# Altium schematic sheet Analog.SchDoc(Analog)
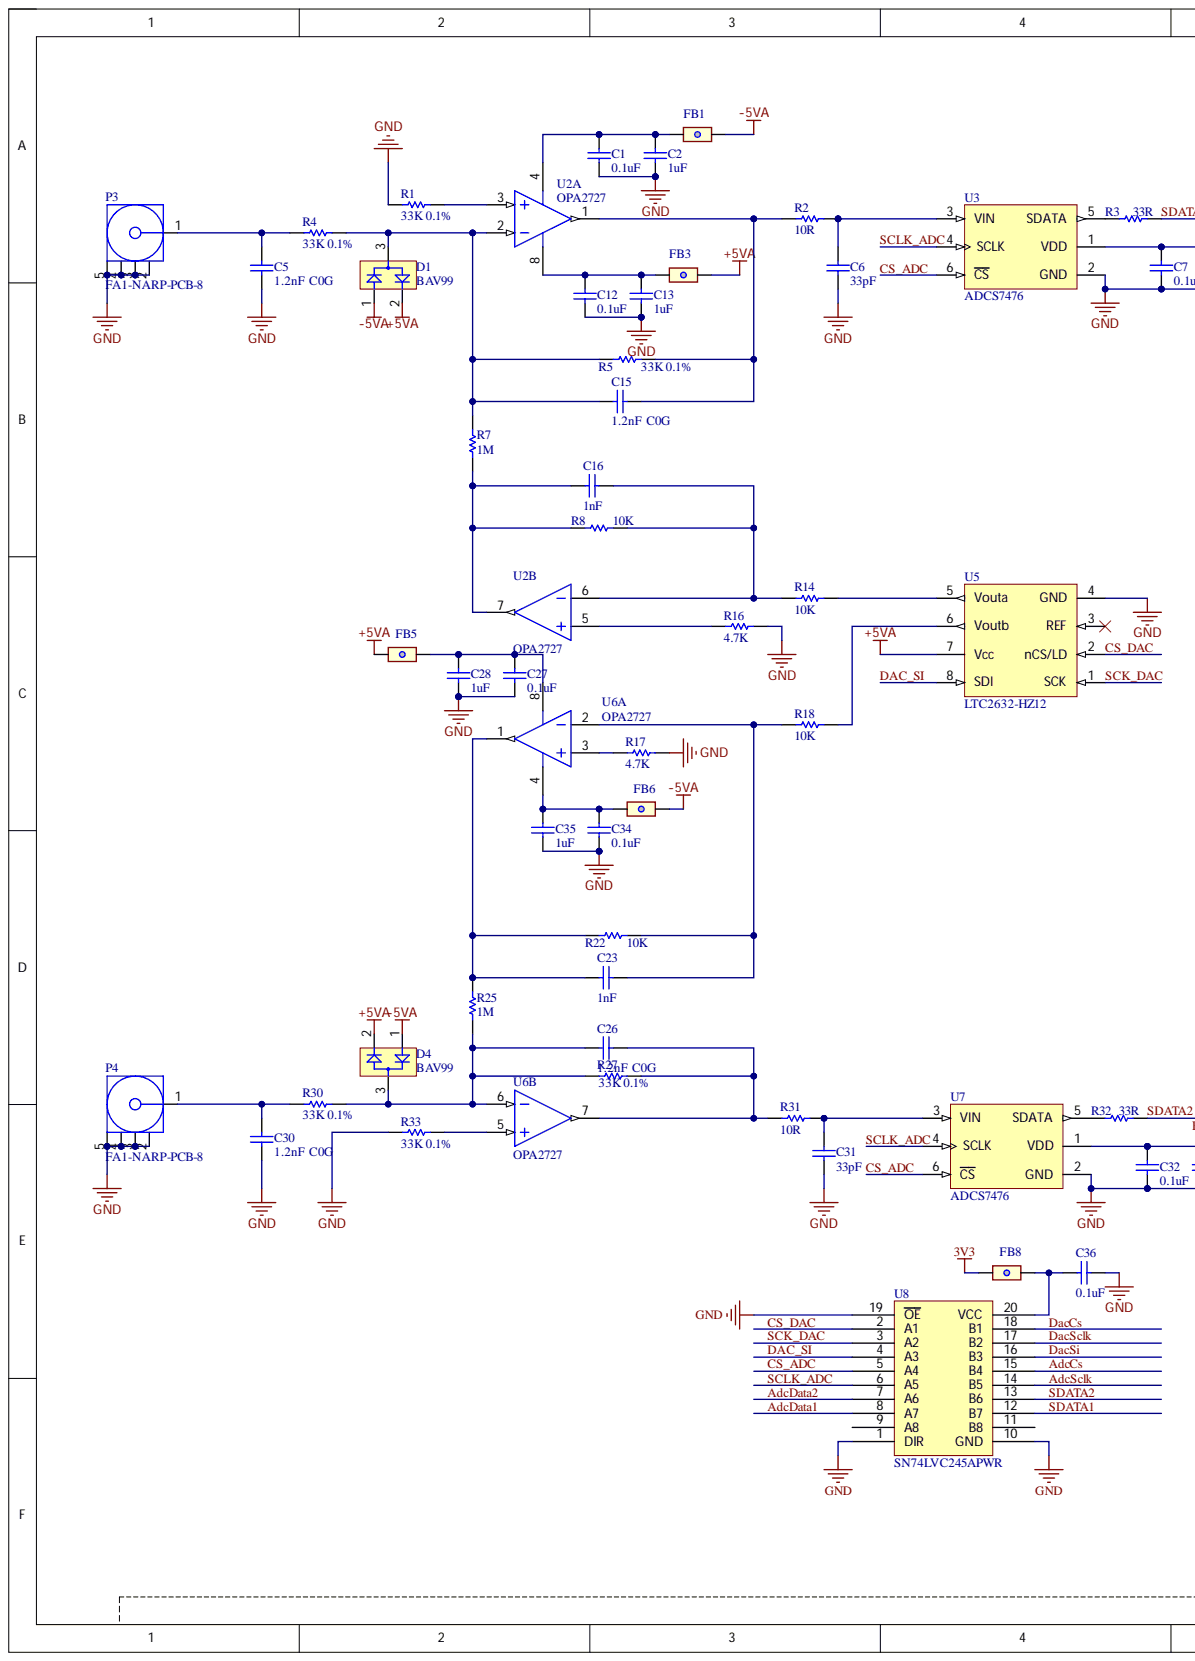
[diagram p0: Analog.SchDoc, left]
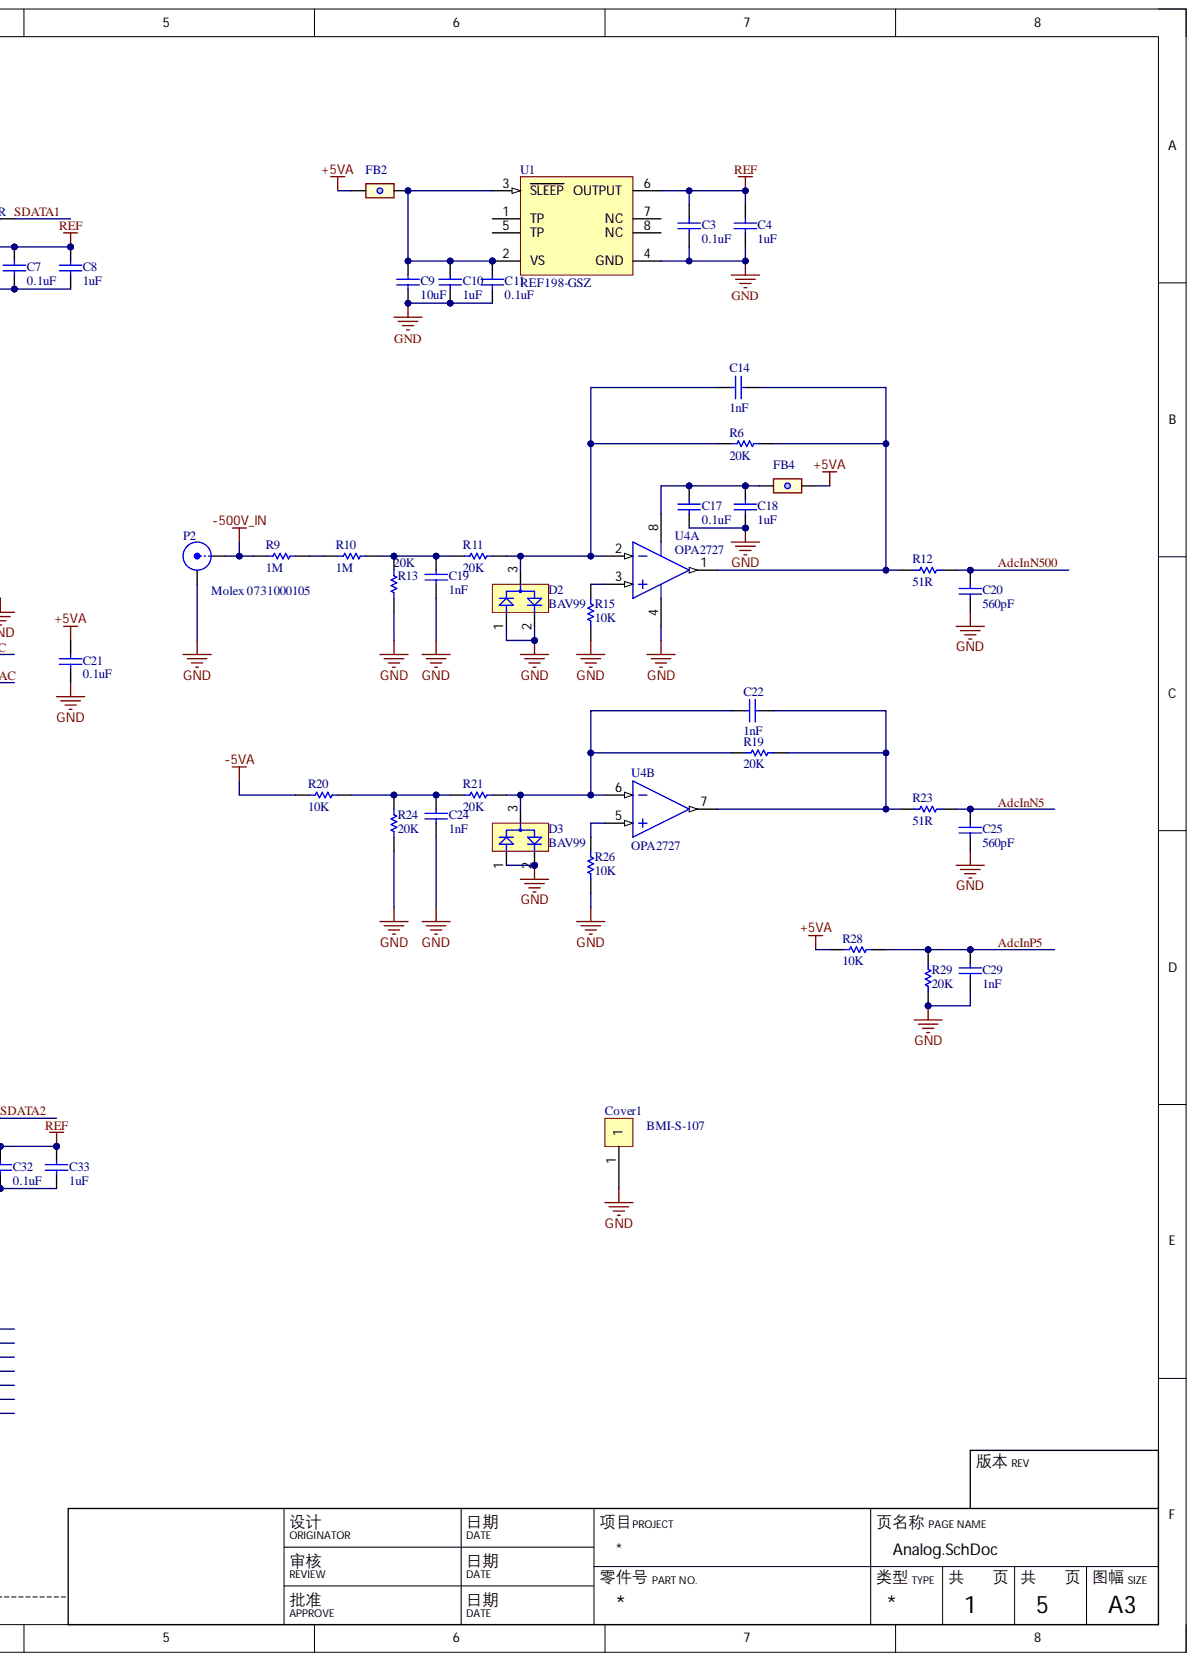
[diagram p1: Analog.SchDoc, right]

## Parts
C1  0.1uF  [C0603(1608)]
C2  1uF  [C0603(1608)]
C3  0.1uF  [C0603(1608)]
C4  1uF  [C0603(1608)]
C5  1.2nF  C0G  [C0603(1608)]
C6  33pF  [C0603(1608)]
C7  0.1uF  [C0603(1608)]
C8  1uF  [C0603(1608)]
C9  10uF  [C1210(3225)]
C10  1uF  [C0603(1608)]
C11  0.1uF  [C0603(1608)]
C12  0.1uF  [C0603(1608)]
C13  1uF  [C0603(1608)]
C14  1nF  [C0603(1608)]
C15  1.2nF  C0G  [C0603(1608)]
C16  1nF  [C0603(1608)]
C17  0.1uF  [C0603(1608)]
C18  1uF  [C0603(1608)]
C19  1nF  [C0603(1608)]
C20  560pF  [C0603(1608)]
C21  0.1uF  [C0603(1608)]
C22  1nF  [C0603(1608)]
C23  1nF  [C0603(1608)]
C24  1nF  [C0603(1608)]
C25  560pF  [C0603(1608)]
C26  1.2nF  C0G  [C0603(1608)]
C27  0.1uF  [C0603(1608)]
C28  1uF  [C0603(1608)]
C29  1nF  [C0603(1608)]
C30  1.2nF  C0G  [C0603(1608)]
C31  33pF  [C0603(1608)]
C32  0.1uF  [C0603(1608)]
C33  1uF  [C0603(1608)]
C34  0.1uF  [C0603(1608)]
C35  1uF  [C0603(1608)]
C36  0.1uF  [C0402(1005)]
Cover1  BMI-S-107  [BMI-S-107]
D1  BAV99  [SOT23-3]
D2  BAV99  [SOT23-3]
D3  BAV99  [SOT23-3]
D4  BAV99  [SOT23-3]
FB1  (no value)  [FB0603(1608)]
FB2  (no value)  [FB0603(1608)]
FB3  (no value)  [FB0603(1608)]
FB4  (no value)  [FB0603(1608)]
FB5  (no value)  [FB0603(1608)]
FB6  (no value)  [FB0603(1608)]
FB8  (no value)  [FB0603(1608)]
P2  Molex 0731000105  制造商: Molex  型号: 0731000105  [Molex 0731000105]
P3  FA1-NARP-PCB-8  [SMB]
P4  FA1-NARP-PCB-8  [SMB]
R1  33K 0.1%  [R0603(1608)]
R2  10R  [R0603(1608)]
R3  33R  [R0603(1608)]
R4  33K 0.1%  [R0603(1608)]
R5  33K 0.1%  [R0603(1608)]
R6  20K  [R0603(1608)]
R7  1M  [R0603(1608)]
R8  10K  [R0603(1608)]
R9  1M  [R1206(3216)]
R10  1M  [R1206(3216)]
R11  20K  [R0603(1608)]
R12  51R  [R0603(1608)]
R13  20K  [R0603(1608)]
R14  10K  [R0603(1608)]
R15  10K  [R0603(1608)]
R16  4.7K  [R0603(1608)]
R17  4.7K  [R0603(1608)]
R18  10K  [R0603(1608)]
R19  20K  [R0603(1608)]
R20  10K  [R0603(1608)]
R21  20K  [R0603(1608)]
R22  10K  [R0603(1608)]
R23  51R  [R0603(1608)]
R24  20K  [R0603(1608)]
R25  1M  [R0603(1608)]
R26  10K  [R0603(1608)]
R27  33K 0.1%  [R0603(1608)]
R28  10K  [R0603(1608)]
R29  20K  [R0603(1608)]
R30  33K 0.1%  [R0603(1608)]
R31  10R  [R0603(1608)]
R32  33R  [R0603(1608)]
R33  33K 0.1%  [R0603(1608)]
U1  REF198-GSZ  [SOIC8_N]
U2  OPA2727  [SOIC8_N]  (parts A,B)
U3  ADCS7476  [ADCS7476]
U4  OPA2727  [SOIC8_N]  (parts A,B)
U5  LTC2632-HZ12  [TSOT23-8]
U6  OPA2727  [SOIC8_N]  (parts A,B)
U7  ADCS7476  [ADCS7476]
U8  SN74LVC245APWR  [TSSOP20_N]

## Nets
3V3: FB8.2
+5VA: C21.2 D1.2 D4.2 FB2.1 FB3.2 FB4.2 FB5.2 R28.1 U5.7(Vcc)
-5VA: D1.1 D4.1 FB1.2 FB6.1 R20.1
-500V_IN: P2.1 R9.1
GND: C1.1 C2.2 C3.1 C4.2 C5.1 C6.1 C7.1 C8.2 C9.2 C10.2 C11.1 C12.1 C13.2 C17.1 C18.2 C19.1 C20.1 C21.1 C24.1 C25.1 C27.1 C28.2 C29.1 C30.1 C31.1 C32.1 C33.2 C34.1 C35.2 C36.2 Cover1.1 D2.1 D2.2 D3.1 D3.2 P2.2 P3.2 P3.3 P3.4 P3.5 P4.2 P4.3 P4.4 P4.5 R1.1 R13.1 R15.1 R16.2 R17.2 R24.1 R26.1 R29.1 R33.1 U1.4(GND) U3.2(GND) U4.4 U5.4(GND) U7.2(GND) U8.1(DIR) U8.10(GND) U8.19(OE)
REF: C3.2 C4.1 C7.2 C8.1 C32.2 C33.1 U1.6(OUTPUT) U3.1(VDD) U7.1(VDD)
AdcCs: U8.15(B4)
AdcData1: U8.8(A7)
AdcData2: U8.7(A6)
AdcInN5: C25.2 R23.2
AdcInN500: C20.2 R12.2
AdcInP5: C29.2 R28.2 R29.2
AdcSclk: U8.14(B5)
CS_ADC: U3.6(CS) U7.6(CS) U8.5(A4)
CS_DAC: U5.2(nCS/LD) U8.2(A1)
DAC_SI: U5.8(SDI) U8.4(A3)
DacCs: U8.18(B1)
DacSclk: U8.17(B2)
DacSi: U8.16(B3)
SCK_DAC: U5.1(SCK) U8.3(A2)
SCLK_ADC: U3.4(SCLK) U7.4(SCLK) U8.6(A5)
SDATA1: R3.1 U8.12(B7)
SDATA2: R32.1 U8.13(B6)
NetC1_2: C1.2 C2.1 FB1.1 U2.4
NetC5_2: C5.2 P3.1 R4.1
NetC6_2: C6.2 R2.2 U3.3(VIN)
NetC9_1: C9.1 C10.1 C11.2 FB2.2 U1.2(VS) U1.3(SLEEP)
NetC12_2: C12.2 C13.1 FB3.1 U2.8
NetC14_1: C14.1 D2.3 R6.1 R11.2 U4.2
NetC14_2: C14.2 R6.2 R12.1 U4.1
NetC15_1: C15.1 R2.1 R5.2 U2.1
NetC15_2: C15.2 D1.3 R4.2 R5.1 R7.2 U2.2
NetC16_1: C16.1 R7.1 R8.1 U2.7
NetC16_2: C16.2 R8.2 R14.1 U2.6
NetC17_2: C17.2 C18.1 FB4.1 U4.8
NetC19_2: C19.2 R10.2 R11.1 R13.2
NetC22_1: C22.1 D3.3 R19.1 R21.2 U4.6
NetC22_2: C22.2 R19.2 R23.1 U4.7
NetC23_1: C23.1 R18.1 R22.1 U6.2
NetC23_2: C23.2 R22.2 R25.2 U6.1
NetC24_2: C24.2 R20.2 R21.1 R24.2
NetC26_1: C26.1 D4.3 R25.1 R27.1 R30.2 U6.6
NetC26_2: C26.2 R27.2 R31.1 U6.7
NetC27_2: C27.2 C28.1 FB5.1 U6.8
NetC30_2: C30.2 P4.1 R30.1
NetC31_2: C31.2 R31.2 U7.3(VIN)
NetC34_2: C34.2 C35.1 FB6.2 U6.4
NetC36_1: C36.1 FB8.1 U8.20(VCC)
NetR1_2: R1.2 U2.3
NetR3_2: R3.2 U3.5(SDATA)
NetR9_2: R9.2 R10.1
NetR14_2: R14.2 U5.5(Vouta)
NetR15_2: R15.2 U4.3
NetR16_1: R16.1 U2.5
NetR17_1: R17.1 U6.3
NetR18_2: R18.2 U5.6(Voutb)
NetR26_2: R26.2 U4.5
NetR32_2: R32.2 U7.5(SDATA)
NetR33_2: R33.2 U6.5

Not connected: U1 1(TP) 5(TP) 7(NC) 8(NC); U5 3(REF); U8 9(A8) 11(B8)

## Library data of the parts
C1 C3 C7 C11 C12 C17 C21 C27 C32 C34 = Cap 0.1uF 0603-50V: ceramic 50V; 价格: ¥0.44@100; 制造商: WURTH ELEKTRONIK; 型号: 885012206095; Component Kind: Standard; Library Name: RCL_release.SchLib; 供应商及库存编号: e络盟 2495187
C2 C4 C8 C10 C13 C18 C28 C33 C35 = Cap 1uF 0603-50V: ceramic 50V; 价格: ¥1.96@100; 制造商: TDK; 型号: CGA3E3X5R1H105K080AB; Component Kind: Standard; Library Name: RCL_release.SchLib; 供应商及库存编号: e络盟 2210922
C5 C15 C26 C30 = Cap 1.2nF 0603-10V: ceramic 10V 2%; 价格: ¥0.32@100; 制造商: Vishay / Vitramon; 型号: VJ0603A122GXQPW1BC; Component Kind: Standard; Library Name: RCL_release.SchLib; 供应商及库存编号: Mouser 77-VJ0603A122GXQPBC
C6 C31 = Cap 33pF 0603-10V: ceramic 10V; 价格: ￥0.14@500; 制造商: WURTH ELEKTRONIK; 型号: 885012006005; Component Kind: Standard; Library Name: RCL_release.SchLib; 供应商及库存编号: e络盟 2533824
C9 = Cap 10 uF 1210（3225）: ceramic 50V; 价格: ¥10@10; 制造商: MURATA; 型号: GRM32ER61H106KA12L; Component Kind: Standard; Library Name: RCL_release.SchLib; 供应商及库存编号: e络盟 1845764
C14 C16 C19 C22-C24 C29 = Cap 1nF 0603-10V: ceramic 10V; 价格: ￥0.49@100; 制造商: KEMET; 型号: C0603C102K8RACTU; Component Kind: Standard; Library Name: RCL_release.SchLib; 供应商及库存编号: e络盟 2581040
C20 C25 = Cap 560pF 0603-25V: ceramic 25V; 价格: ￥1.576@10; 制造商: KEMET; 型号: C0603C561K3RACTU; Component Kind: Standard; Library Name: RCL_release.SchLib; 供应商及库存编号: Digikey：399-7941-1-ND
C36 = Cap 0.1uF 0402-50V: ceramic 50V; 价格: ¥0.375@100; 制造商: TDK; 型号: C1005X7R1H104K050BB; Component Kind: Standard; Library Name: RCL_release.SchLib; 供应商及库存编号: e络盟 2525047
Cover1 = BMI-S-107: EMI Cover 44.37*44.37*9.75mm; Component Kind: Standard; Library Name: Connector_temp.SchLib
D1-D4 = BAV99: DIODE ARRAY 100V 215MA SOT23-3; 价格: $0.47@100; 制造商: ON Semiconductor; 名称: 二极管; 型号: BAV99LT1G; Component Kind: Standard; Library Name: Transistor_release.SchLib; 供应商及库存编号: e络盟 1431118
FB1-FB6 FB8 = FB-1K@100MHz 0603: FERRITE BEAD 1 KOHM 0603 1LN; 价格: ¥0.25@100; 制造商: MURATA; 名称: 磁珠; 型号: BLM18AG102SN1D; Component Kind: Standard; Library Name: RCL_release.SchLib; 供应商及库存编号: e络盟 1515671
P2 = Molex 0731000105: CONN BNC RCPT R/A 50 OHM PCB; 价格: $1.34@10; Component Kind: Standard; Library Name: Connector_temp.SchLib; 供应商及库存编号: digikey：WM5524-ND
P3 P4 = FA1-NARP-PCB-8: FAKRA PLUG R/A 50 OHM PCB; 价格: $2.28@100; 制造商: Amphenol RF Division; 型号: FA1-NARP-PCB-8+FA1-NASJ-C04-0; Component Kind: Standard; Library Name: Connector_temp.SchLib; 供应商及库存编号: digikey ARF1655-ND+ARF1268-ND
R1 R4 R5 R27 R30 R33 = Res 33K 0603  0.1%: Res ±0.1%; 价格: ￥0.617; 制造商: MULTICOMP PRO; 型号: MCWF06R3302BTL; Component Kind: Standard; Library Name: RCL_release.SchLib; 供应商及库存编号: E络盟：2337138
R2 R31 = Res 10R 0603: Res ±1%; Component Kind: Standard; Library Name: RCL_release.SchLib
R3 R32 = Res 33R 0603: Res ±1%; Component Kind: Standard; Library Name: RCL_release.SchLib
R6 R11 R13 R19 R21 R24 R29 = Res 20K 0603: Res ±1%; Component Kind: Standard; Library Name: RCL_release.SchLib
R7 R25 = Res 1M 0603: Res ±1%; Component Kind: Standard; Library Name: RCL_release.SchLib
R8 R14 R15 R18 R20 R22 R26 R28 = Res 10K 0603: Res ±1%; Component Kind: Standard; Library Name: RCL_release.SchLib
R9 R10 = Res 1M 1206: Res ±0.1%; 价格: ￥0.44@10; 制造商: Yageo; 型号: RT1206BRD071ML; Component Kind: Standard; Library Name: RCL_release.SchLib; 供应商及库存编号: Digikey： YAG2022CT-ND
R12 R23 = Res 51R 0603: Res ±1%; Component Kind: Standard; Library Name: RCL_release.SchLib
R16 R17 = Res 4.7K 0603: Res ±1%; Component Kind: Standard; Library Name: RCL_release.SchLib
U1 = REF198-GSZ: IC VREF SERIES 4.096V 8SOIC; 价格: ￥27.2@1; 制造商: *ADI; 型号: REF198GSZ; Component Kind: Standard; Library Name: IC_temp.SchLib; 供应商及库存编号: Digikey： REF198GSZ-ND
U2 U4 U6 = OPA2727: 2 Circuit Rail-to-Rail 20MHZ RRO 8SOIC; 价格: $2.106@100; 制造商: Texas Instruments; 型号: OPA2727AID; Component Kind: Standard; Library Name: IC_temp.SchLib; 供应商及库存编号: digikey 296-33473-5-ND
U3 U7 = ADCS7476: ADC 12BIT 1MSPS SOT-23-6; 价格: $1.56@1K; 制造商: Texas Instruments; 型号: ADCS7476AIMF/NOPB; Component Kind: Standard; Library Name: IC_temp.SchLib; 供应商及库存编号: digikey ADCS7476AIMF/NOPBTR-ND
U5 = LTC2632-HZ12: DAC 12BIT SPI/SRL TSOT-23-8; 价格: $3.745@100; 制造商: Linear Technology; 型号: LTC2632CTS8-HZ12#TRMPBF; Component Kind: Standard; Library Name: IC_temp.SchLib; 供应商及库存编号: digikey LTC2632CTS8-HZ12#TRMPBFCT-ND
U8 = SN74LVC245APWR: BUS TRANSCEIVER 8BIT 20TSSOP; 价格: $0.162@2K; 制造商: Texas Instruments; Component Kind: Standard; Library Name: IC.SchLib; 供应商及库存编号: digikey 296-1233-2-ND; 型号: SN74LVC245APWR
